# Altium schematic sheet Master.SchDoc
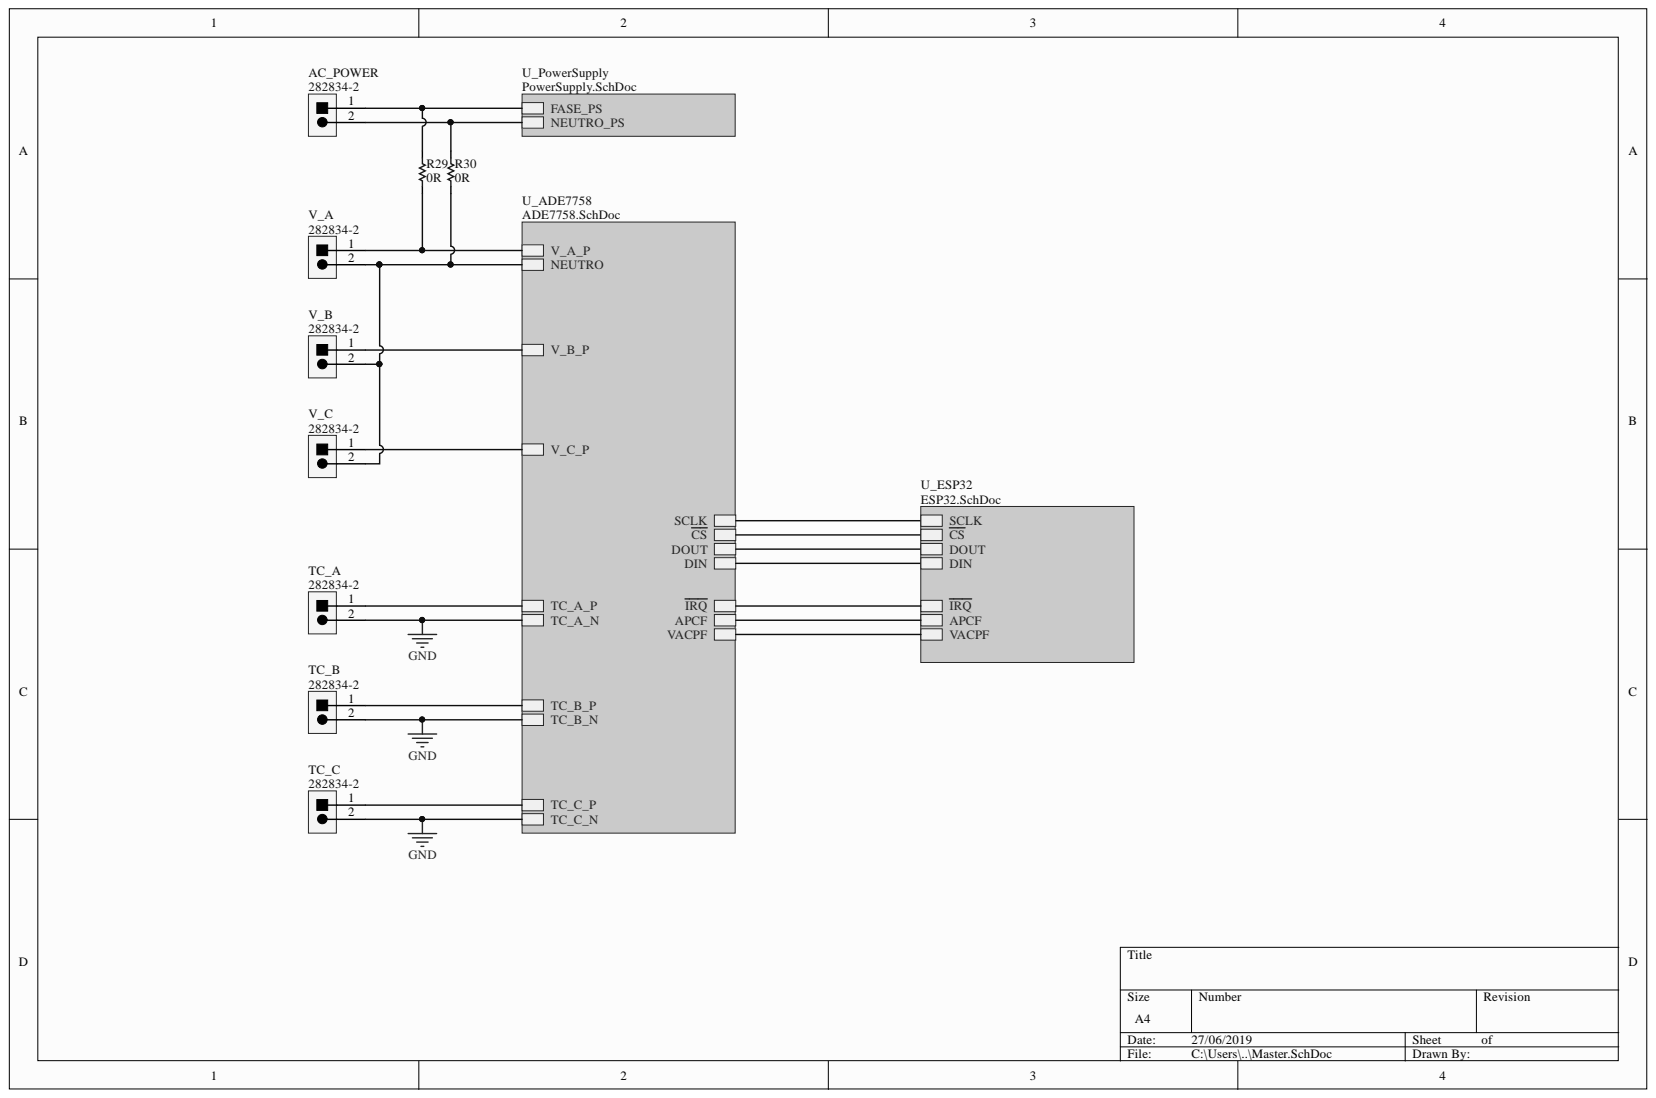
[diagram p0: Master.SchDoc]

## Parts
AC_POWER  Part Number: 282834-2  [TEC_282834-2]  (hidden comment: Conector)
R29  value 0R  [RES0603]  (hidden comment: Resistor)
R30  value 0R  [RES0603]  (hidden comment: Resistor)
TC_A  Part Number: 282834-2  [TEC_282834-2]  (hidden comment: Conector)
TC_B  Part Number: 282834-2  [TEC_282834-2]  (hidden comment: Conector)
TC_C  Part Number: 282834-2  [TEC_282834-2]  (hidden comment: Conector)
V_A  Part Number: 282834-2  [TEC_282834-2]  (hidden comment: Conector)
V_B  Part Number: 282834-2  [TEC_282834-2]  (hidden comment: Conector)
V_C  Part Number: 282834-2  [TEC_282834-2]  (hidden comment: Conector)

## Nets
GND: TC_A.2 TC_B.2 TC_C.2
NetAC_POWER_1: AC_POWER.1 R29.1
NetAC_POWER_2: AC_POWER.2 R30.1
NetR29_2: R29.2 V_A.1
NetR30_2: R30.2 V_A.2 V_B.2 V_C.2
NetTC_A_1: TC_A.1
NetTC_B_1: TC_B.1
NetTC_C_1: TC_C.1
NetV_B_1: V_B.1
NetV_C_1: V_C.1
APCF:
~{CS}:
DIN:
DOUT:
~{IRQ}:
SCLK:
VACPF:

## Library data of the parts
AC_POWER TC_A TC_B TC_C V_A V_B V_C = Conector 2x1: TERM BLOCK 2POS SIDE ENT 2.54MM; Manufacturer: TE Connectivity AMP Connectors; Component Kind: Standard; PCB Info: -; Value: -; Library Name: Simbolos.SchLib
R29 R30 = Resistor: Component Kind: Standard; Description: Resistor; Library Name: Simbolos.SchLib
